# Altium schematic sheet 03. POWER SUPPLY.SchDoc
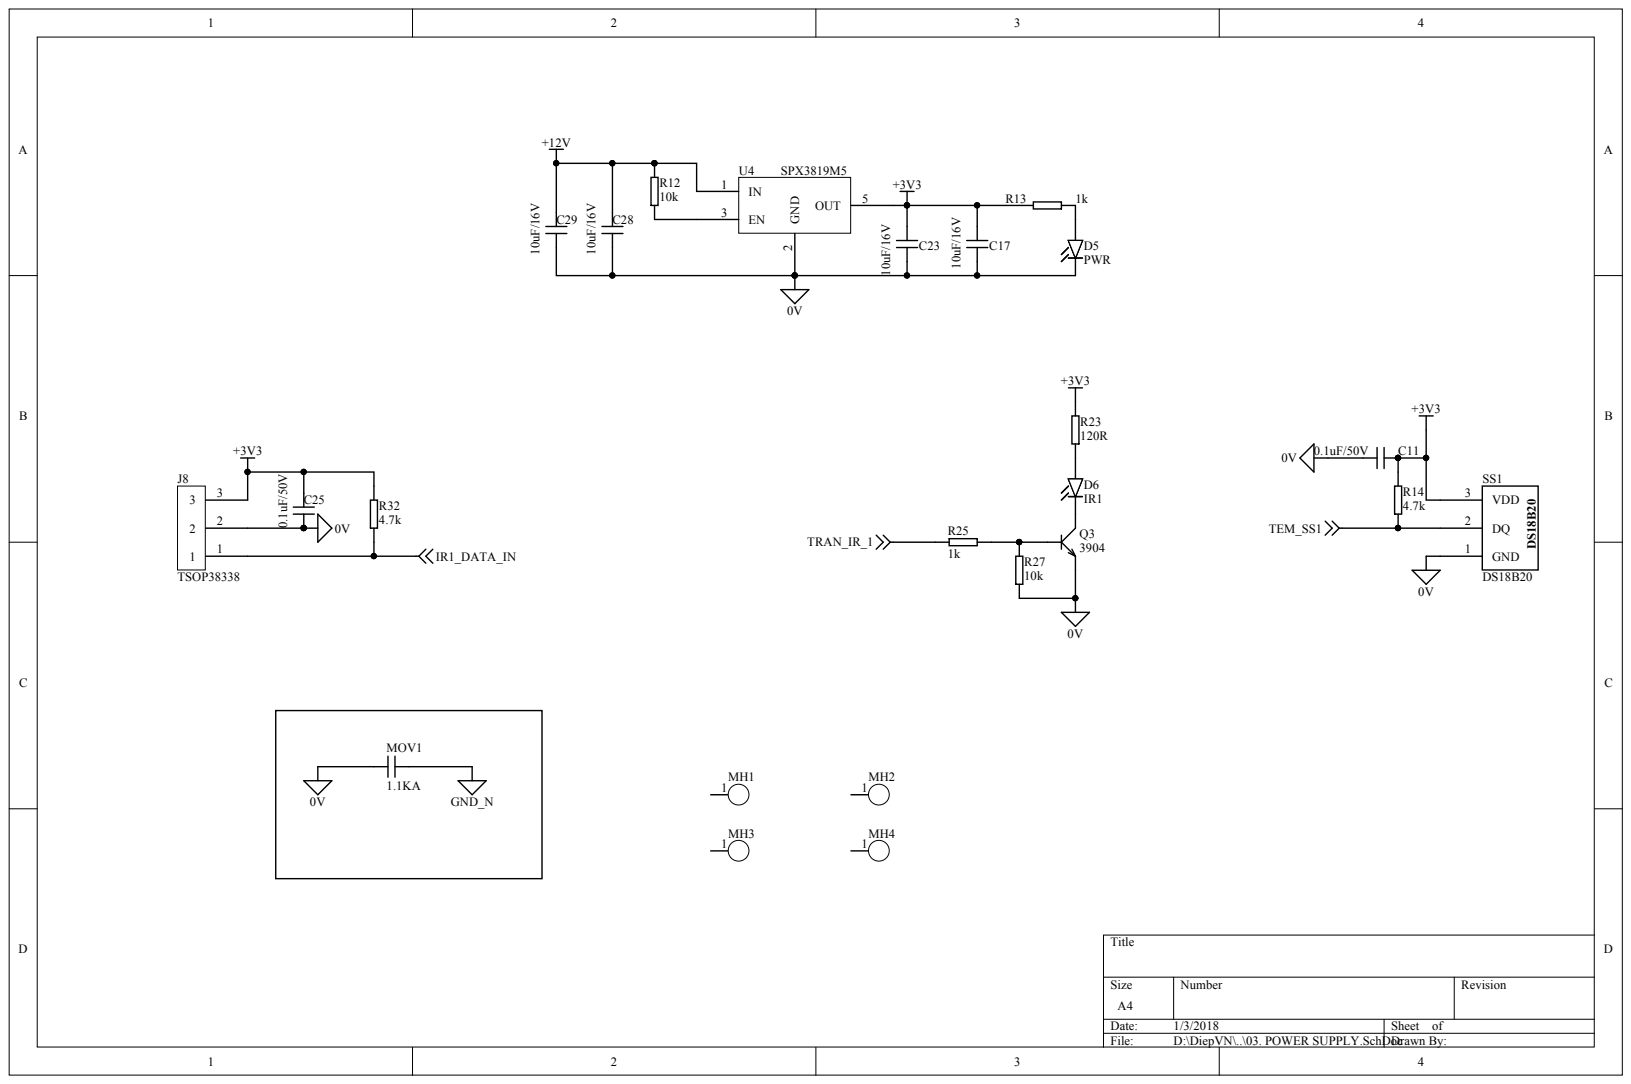
[diagram p0: 03. POWER SUPPLY.SchDoc]

## Parts
C11  0.1uF/50V  [0805]
C17  10uF/16V  [0805]
C23  10uF/16V  [0805]
C25  0.1uF/50V  [0805]
C28  10uF/16V  [0805]
C29  10uF/16V  [0805]
D5  PWR  [0805_Pol]
D6  IR1  [LED-2.54]
J8  TSOP38338  [Header 3x1_2.54mm]
MH1  MH  [SCREW_3x6]
MH2  MH  [SCREW_3x6]
MH3  MH  [SCREW_3x6]
MH4  MH  [SCREW_3x6]
MOV1  1.1KA  [DIS_14_SPAC_7.5]
Q3  3904  [SOT-23]
R12  10k  [0805]
R13  1k  [0805]
R14  4.7k  [0805]
R23  120R  [0805]
R25  1k  [0805]
R27  10k  [0805]
R32  4.7k  [0805]
SS1  DS18B20  [Header 3x1_2.54mm]
U4  SPX3819M5  [SOT23_5]

## Nets
0V: C11.1 C17.2 C23.1 C25.1 C28.2 C29.2 D5.2 J8.2 MOV1.1 Q3.2 R27.1 SS1.1 U4.2(GND)
+3V3: C11.2 C17.1 C23.2 C25.2 J8.3 R13.2 R14.2 R23.2 R32.2 SS1.3 U4.5(OUT)
+12V: C28.1 C29.1 R12.2 U4.1(IN)
GND_N: MOV1.2
IR1_DATA_IN: J8.1 R32.1
TEM_SS1: R14.1 SS1.2
TRAN_IR_1: R25.1
NetD5_1: D5.1 R13.1
NetD6_1: D6.1 R23.1
NetD6_2: D6.2 Q3.3
NetQ3_1: Q3.1 R25.2 R27.2
NetR12_1: R12.1 U4.3(EN)

Not connected: MH1 1; MH2 1; MH3 1; MH4 1

## Library data of the parts
C11 C25 = Cap_0.1uF: CAP CER 0.1UF 50V Y5V 0805; MFR: Yageo; Part Number: CC0805ZRY5V9BB104; ASS: SMT; Component Kind: Standard; Package: 0805; Library Name: Schlib_Diepvn_V01.SchLib
C17 C28 C29 = Cap_10uF: CAP CER 10UF 16V X5R 0805; MFR: Murata; Part Number: GRM21BR61C106KE15K; ASS: SMT; Component Kind: Standard; Package: 0805; Library Name: Schlib_Diepvn_V01.SchLib
C23 = Cap_10uF: CAP CER 10UF 16V X5R 0805; MFR: Murata; Part Number: GRM21BR61C106KE15K; ASS: SMT; BA Code: VT-00984; Component Kind: Standard; Package: 0805; Library Name: Schlib_Diepvn_V01.SchLib
D5 = LED_Gr: LED RED CLEAR 0805 SMD; MFR: Lite-On; Part Number: LTST-C171KRKT; Component Kind: Standard; Package: 0805; Library Name: Schlib_Diepvn_V01.SchLib
D6 = LED_BLUE: LED 3MM HI EFF BLUE DIFF; MFR: Vishay Semiconductor Opto Division; Part Number: TLHB4400; Vender Part: 751-1092-ND; Vender: Digikey; Component Kind: Standard; Package: Pitch:3mm; Library Name: Schlib_Diepvn_V01.SchLib
J8 = Header 3x1: IC IR RCVR MOD 38KHZ DOME RADIAL; MFR: Vishay Semiconductor Opto Division; Component Kind: Standard; Package: Pitch: 2.54MM 3POS; Part Number: TSOP38338; Library Name: Schlib_Diepvn_V01.SchLib
MH1-MH4 = Test Point Huge: 3x6mm; Component Kind: Standard; Library Name: Schlib_Diepvn_V01.SchLib
MOV1 = MOV-2kV: VARISTOR 1100V 5KA DISC 13MM / GDT 2000V 3KA 2 POLE SMD; MFR: LF; Part Number: ERZ-E11A112; Part Number 1: CG42.0SM; Component Kind: Standard; Package: refer to datasheet; Library Name: Schlib_Diepvn_V01.SchLib
Q3 = MMBT3904: TRANS NPN 40V 0.6A SMD SOT23-3; MFR: Diode Inc; Part Number: MMBT2222A-7-F; ASS: SMT; BA Code: VT-00141; Component Kind: Standard; Package: SOT-23; Library Name: Schlib_Diepvn_V01.SchLib
R12 R27 = Res_10k: RES SMD 10K OHM 1% 1/8W 0805; MFR: Yageo; Part Number: RC0805FR-0710KL; ASS: SMT; BA Code: VT-00575; Component Kind: Standard; Package: 0805; Library Name: Schlib_Diepvn_V01.SchLib
R13 R25 = Res_1k: RES SMD 1K OHM 1% 1/8W 0805; MFR: Yageo; Part Number: RC0805FR-071KL; ASS: SMT; BA Code: VT-00574; Component Kind: Standard; Package: 0805; Library Name: Schlib_Diepvn_V01.SchLib
R14 R32 = Res_4.7k: RES SMD 4.7K OHM 1% 1/8W 0805; MFR: Yageo; Part Number: RC0805FR-074K7L; ASS: SMT; Component Kind: Standard; Package: 0805; Library Name: Schlib_Diepvn_V01.SchLib
R23 = Res_100R: RES SMD 120 OHM 1% 1/8W 0805; MFR: Yageo; Part Number: RC0805FR-07120RL; Component Kind: Standard; Package: 0805; Library Name: Schlib_Diepvn_V01.SchLib
SS1 = DS18B20: SENSOR TEMPERATURE 1-WIRE TO92-3; Component Kind: Standard; Package: Pitch: 2.54mm; Library Name: Schlib_Diepvn_V01.SchLib
U4 = TLV70233: IC REG LDO 3.3V 0.5A SOT23-5, INPUT max 16V; MFR: Exar; Part Number: SPX3819M5-L-3-3/TR; Part Number 1: LDK320AM33R; Component Kind: Standard; Package: SOT23-5; Part Number 2: AP2210K-3.3TRG1; Library Name: Schlib_Diepvn_V01.SchLib
